# Altium schematic sheet POWER_12OUT_CH3.SchDoc
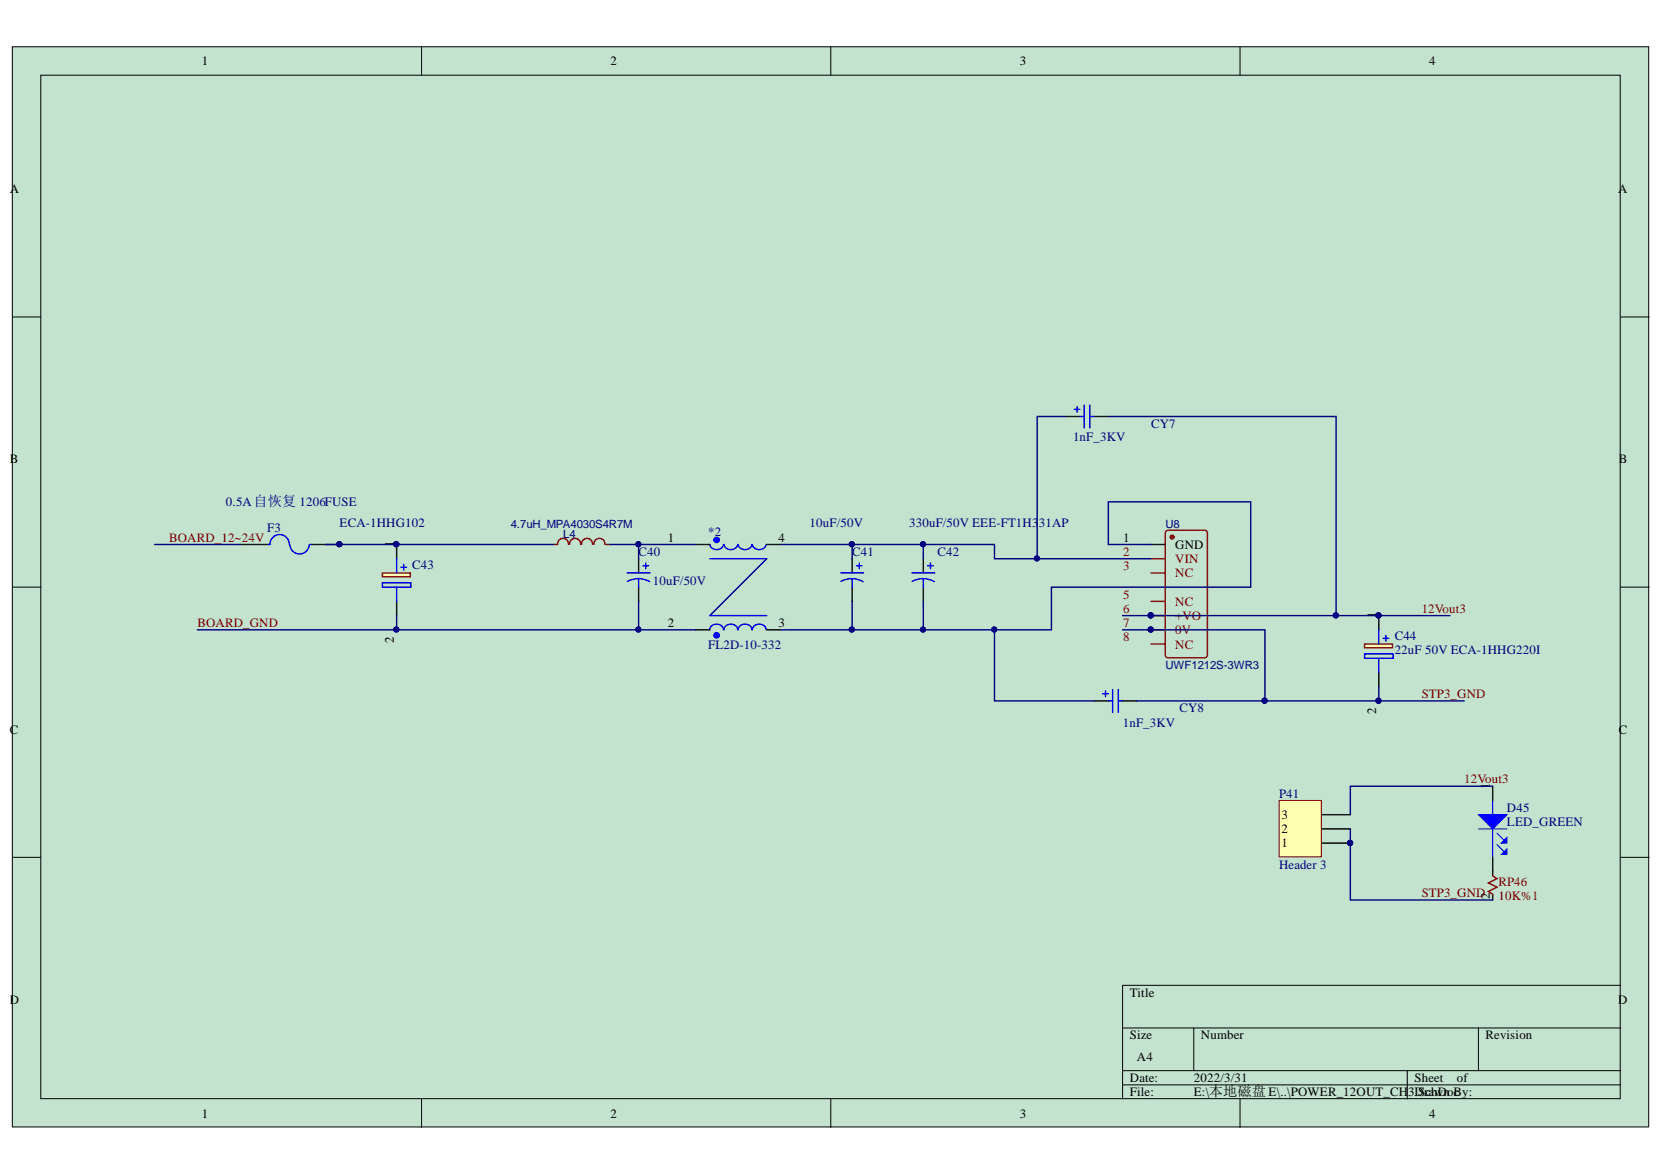
[diagram p0: POWER_12OUT_CH3.SchDoc]

## Parts
*2  FL2D-10-332  [FL2D-XX-XXX]
C40  10uF/50V  [C1210_N]
C41  10uF/50V  [C1210_N]
C42  330uF/50V EEE-FT1H331AP  [EEE0JA152UAP]
C43  ECA-1HHG102  [CAP12.5*25]
C44  22uF 50V ECA-1HHG220I  [CAPPRD200W55D500H1200]
CY7  1nF_3KV  [CAP1808]
CY8  1nF_3KV  [CAP1808]
D45  LED_GREEN  [LED0603]
F3  0.5A 自恢复 1206FUSE  [1206_FUSE]
L4  4.7uH_MPA4030S4R7M  [IND-SMD_L5.2-W5.2_MS0530-XX]
P41  Header 3  [HDR1X3]
RP46  10K%1  [R0805]
U8  UWF1212S-3WR3  [PWRM-TH_UWFXXXXS-3WR3]

## Nets
12VOUT3: C44.1 CY7.2 D45.1 P41.3 U8.6(+VO)
BOARD_12~24V: F3.1
BOARD_GND: *2.2 C40.2 C43.2
STP3_GND: C44.2 CY8.2 P41.1 P41.2 RP46.2 U8.7(0V)
Net*2_1: *2.1 C40.1 L4.2
Net*2_3: *2.3 C41.2 C42.2 CY8.1 U8.1(GND)
Net*2_4: *2.4 C41.1 C42.1 CY7.1 U8.2(VIN)
NetC43_1: C43.1 F3.2 L4.1
NetD45_2: D45.2 RP46.1

Not connected: U8 3(NC) 5(NC) 8(NC)

## Library data of the parts
*2 = FL2D: Component Kind: Standard; Library Name: Schlib1.SchLib
C40-C42 = Cap Pol2: Polarized Capacitor (Axial); Value: 10uF; Component Kind: Standard; Library Name: Miscellaneous Devices.IntLib; Published: 8-Jun-2000; Revision: July-2002: Re-released for DXP Platform.; Signal Integrity: Cap Pol; Simulation: CAP; Publisher: Altium Limited
C43 = ECA-1HHG102: Capacitor Polarised; Manufacturer_Name: Panasonic; Component Kind: Standard; Datasheet Link: https://componentsearchengine.com//ECA-1HHG102.pdf; Height: 27mm; Manufacturer_Part_Number: ECA-1HHG102; Mouser2 Part Number: 667-ECA-1HHG102; Mouser2 Price/Stock: https://www.mouser.com/Search/Refine.aspx?Keyword=667-ECA-1HHG102
C44 = ECA-1HHG220I: Capacitor Polarised; Manufacturer_Name: Panasonic; Component Kind: Standard; Datasheet Link: http://industrial.panasonic.com/cdbs/www-data/pdf/RDF0000/ABA0000C1215.pdf; Height: 12mm; Manufacturer_Part_Number: ECA-1HHG220I
CY7 CY8 = Cap Pol2: Polarized Capacitor (Axial); Component Kind: Standard; LatestRevisionDate: 17-Jul-2002; LatestRevisionNote: Re-released for DXP Platform.; Library Name: Miscellaneous Devices.IntLib; PackageDescription: Polarized Capacitor; 2 Leads; PackageReference: POLAR0.8; Published: 8-Jun-2000; Signal Integrity: Cap Pol; Simulation: CAP; Publisher: Altium Limited
D45 = LED_0: LED GRN SGL 25MA 0603; Component Kind: Standard; Library Name: 野火_F429_V2核心板_原理图封装库_AD格式.lib
F3 = Fuse 2: Fuse; Component Kind: Standard; LatestRevisionDate: 17-Jul-2002; LatestRevisionNote: Re-released for DXP Platform.; PackageReference: PIN-W2/E2.8; Published: 8-Jun-2000; Simulation: FUSE; Library Name: jinrylib.IntLib; Publisher: Altium Limited
L4 = 4.7uH: BOM_Manufacturer: COILMX; BOM_Manufacturer Part: MS0530-4R7M; Contributor: LCSC; nameAlias: Inductance; BOM_JLCPCB Part Class: Extended Part; BOM_Supplier: LCSC; BOM_Supplier Part: C380165; Component Kind: Standard; Library Name: Schlib1.SchLib; spicePre: L; spiceSymbolName: MS0530-4R7M
P41 = Header 3: Header, 3-Pin; Component Kind: Standard; LatestRevisionDate: 17-Jul-2002; LatestRevisionNote: Re-released for DXP Platform.; Library Name: Miscellaneous Connectors.IntLib; Signal Integrity: Connector; Publisher: Altium Limited
RP46 = RESISTOR: Generic Resistor; Value: 4.7K; Component Kind: Standard; Library Name: Digilent.IntLib
U8 = UWF1212S-3WR3: BOM_Manufacturer: MORNSUN(金升阳); Contributor: LCSC; BOM_JLCPCB Part Class: Extended Part; BOM_Manufacturer Part: UWF1212S-3WR3; BOM_Supplier: LCSC; BOM_Supplier Part: C2684865; Component Kind: Standard; Library Name: Schlib1.SchLib; spicePre: U; spiceSymbolName: UWF1212S-3WR3
